# Altium schematic sheet mmb-2016-003_micro_phy.schdoc(mmb-2016-003_micro_phy)
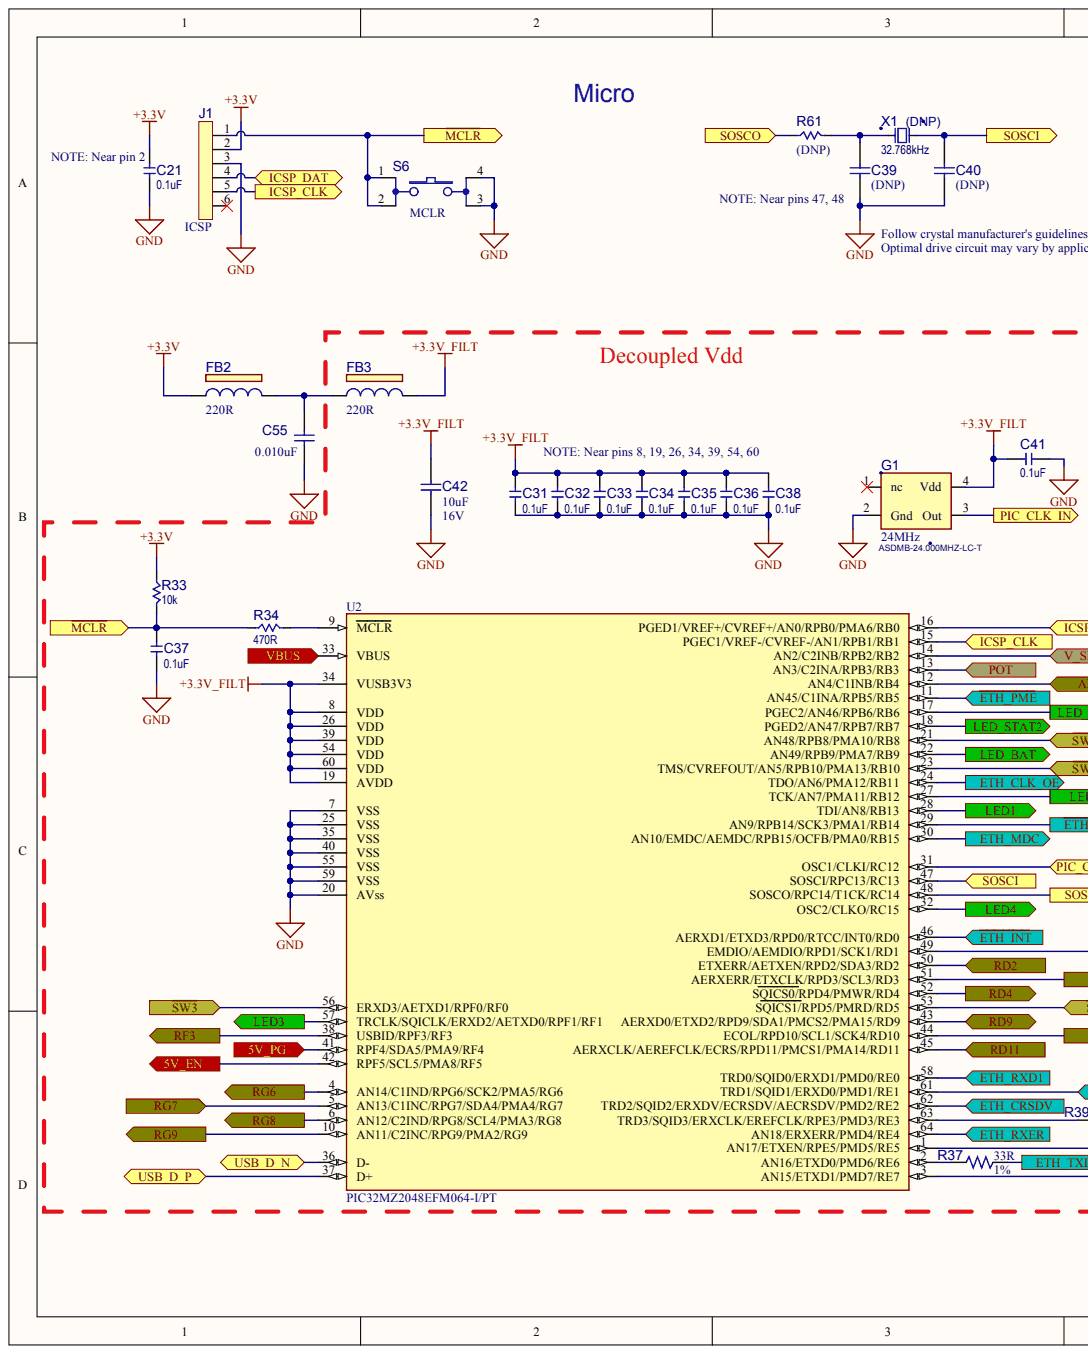
[diagram p0: mmb-2016-003_micro_phy.schdoc, left]
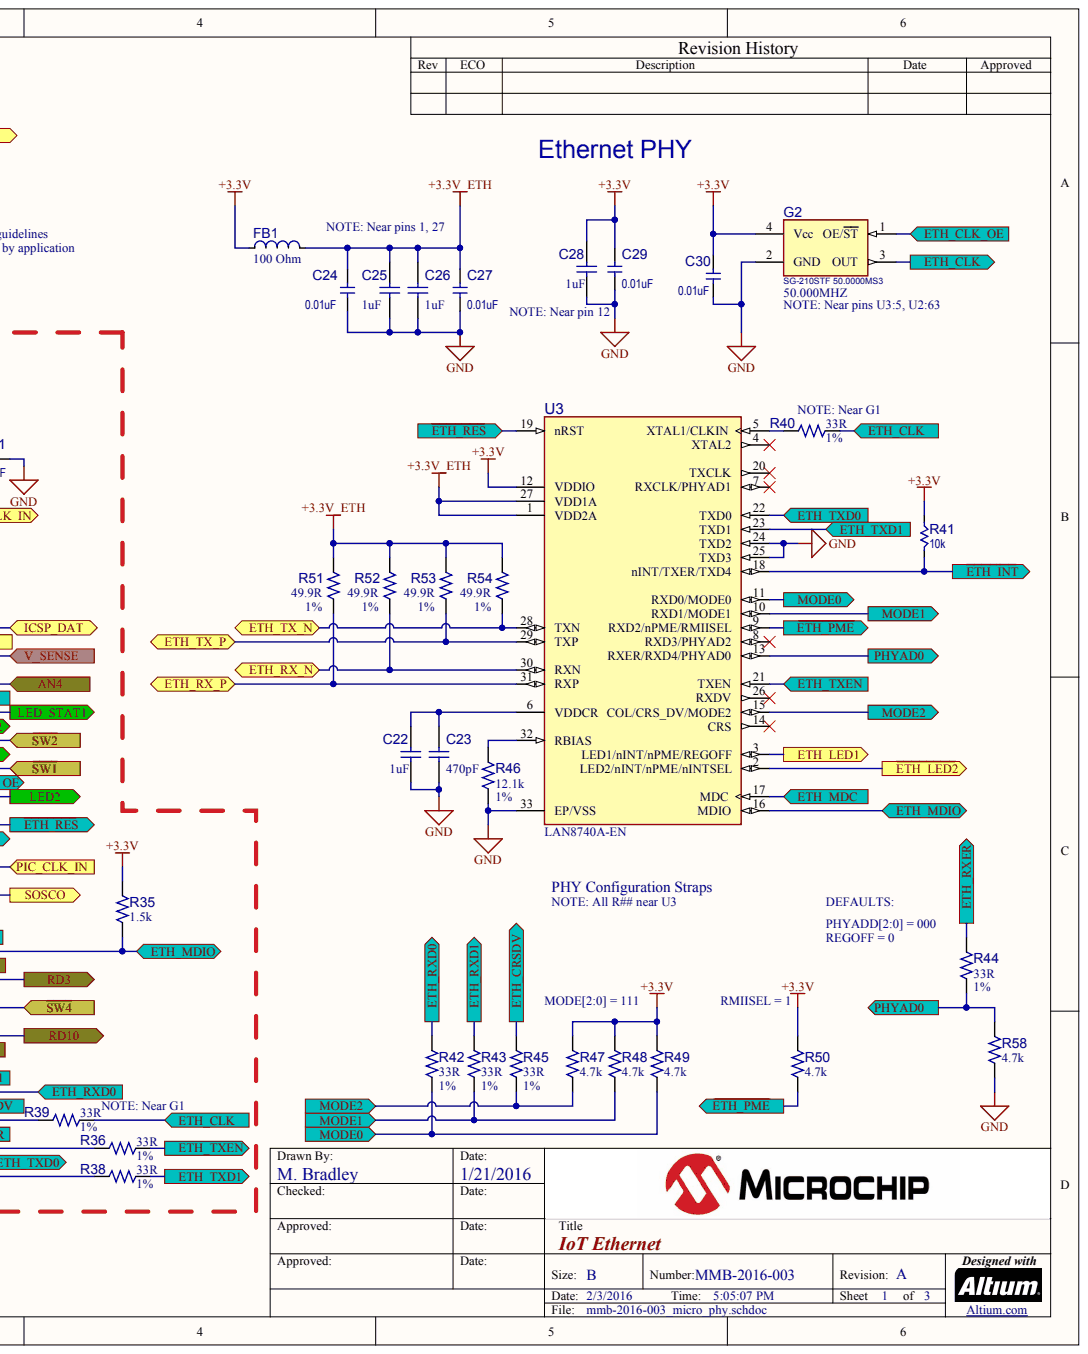
[diagram p1: mmb-2016-003_micro_phy.schdoc, right]

## Parts
C21  value 0.1uF  [CAP_C_0603]  (hidden comment: Capacitor NP)
C22  value 1uF  [CAP_C_0603]  (hidden comment: Capacitor NP)
C23  value 470pF  [CAP_C_0603]  (hidden comment: Capacitor NP)
C24  value 0.01uF  [CAP_C_0603]  (hidden comment: Capacitor NP)
C25  value 1uF  [CAP_C_0603]  (hidden comment: Capacitor NP)
C26  value 1uF  [CAP_C_0603]  (hidden comment: Capacitor NP)
C27  value 0.01uF  [CAP_C_0603]  (hidden comment: Capacitor NP)
C28  value 1uF  [CAP_C_0603]  (hidden comment: Capacitor NP)
C29  value 0.01uF  [CAP_C_0603]  (hidden comment: Capacitor NP)
C30  value 0.01uF  [CAP_C_0603]  (hidden comment: Capacitor NP)
C31  value 0.1uF  [CAP_C_0603]  (hidden comment: Capacitor NP)
C32  value 0.1uF  [CAP_C_0603]  (hidden comment: Capacitor NP)
C33  value 0.1uF  [CAP_C_0603]  (hidden comment: Capacitor NP)
C34  value 0.1uF  [CAP_C_0603]  (hidden comment: Capacitor NP)
C35  value 0.1uF  [CAP_C_0603]  (hidden comment: Capacitor NP)
C36  value 0.1uF  [CAP_C_0603]  (hidden comment: Capacitor NP)
C37  value 0.1uF  [CAP_C_0603]  (hidden comment: Capacitor NP)
C38  value 0.1uF  [CAP_C_0603]  (hidden comment: Capacitor NP)
C39  (DNP)  [CAP_C_0603]  (no BOM)
C40  (DNP)  [CAP_C_0603]  (no BOM)
C41  value 0.1uF  [CAP_C_0603]  (hidden comment: Capacitor NP)
C42  value 10uF  Voltage: 16V  [CAP_C_0805]  (hidden comment: (DNP))
C55  value 0.010uF  [CAP_C_0603]  (hidden comment: Capacitor NP)
FB1  value 100 Ohm  [IND_C_0603]  (hidden comment: Inductor)
FB2  value 220R  [IND_C_0805]  (hidden comment: Ferrite Bead)
FB3  value 220R  [IND_C_0805]  (hidden comment: Ferrite Bead)
G1  ASDMB-24.000MHZ-LC-T  value 24MHz  [CRYSTAL_ASDMB]
G2  SG-210STF 50.0000MS3  value 50.000MHZ  [CRYSTAL OSC_SG-210STF_L2.5W2.0H0.8]
J1  ICSP  [HDR_M_2.54_1x6_RA]
R33  value 10k  [RES_C_0603]  (hidden comment: Resistor)
R34  value 470R  [RES_C_0603]  (hidden comment: Resistor)
R35  value 1.5k  [RES_C_0603]  (hidden comment: Resistor)
R36  value 33R  Tolerance: 1%  [RES_C_0603]  (hidden comment: Resistor)
R37  value 33R  Tolerance: 1%  [RES_C_0603]  (hidden comment: Resistor)
R38  value 33R  Tolerance: 1%  [RES_C_0603]  (hidden comment: Resistor)
R39  value 33R  Tolerance: 1%  [RES_C_0603]  (hidden comment: Resistor)
R40  value 33R  Tolerance: 1%  [RES_C_0603]  (hidden comment: Resistor)
R41  value 10k  [RES_C_0603]  (hidden comment: Resistor)
R42  value 33R  Tolerance: 1%  [RES_C_0603]  (hidden comment: Resistor)
R43  value 33R  Tolerance: 1%  [RES_C_0603]  (hidden comment: Resistor)
R44  value 33R  Tolerance: 1%  [RES_C_0603]  (hidden comment: Resistor)
R45  value 33R  Tolerance: 1%  [RES_C_0603]  (hidden comment: Resistor)
R46  value 12.1k  Tolerance: 1%  [RES_C_0603]  (hidden comment: Resistor)
R47  value 4.7k  [RES_C_0603]  (hidden comment: Resistor)
R48  value 4.7k  [RES_C_0603]  (hidden comment: Resistor)
R49  value 4.7k  [RES_C_0603]  (hidden comment: Resistor)
R50  value 4.7k  [RES_C_0603]  (hidden comment: Resistor)
R51  value 49.9R  Tolerance: 1%  [RES_C_0603]  (hidden comment: Resistor)
R52  value 49.9R  Tolerance: 1%  [RES_C_0603]  (hidden comment: Resistor)
R53  value 49.9R  Tolerance: 1%  [RES_C_0603]  (hidden comment: Resistor)
R54  value 49.9R  Tolerance: 1%  [RES_C_0603]  (hidden comment: Resistor)
R58  value 4.7k  [RES_C_0603]  (hidden comment: Resistor)
R61  (DNP)  [RES_C_0603]  (no BOM)
S6  MCLR  [SW_SMD_6mmX6mm_TL3301]
U2  PIC32MZXXXXEFM064 QFN-64_TQFP-64  [TQFP-64_10x10]  (value not drawn)
U3  LAN8740A-EN  [QFN-32_5X5]
X1  (DNP)  value 32.768kHz  [CRYSTAL_ABS07]  (no BOM)

## Ports
5V_EN, 5V_PG, AN4, ETH_CLK, ETH_CLK_OE, ETH_CRSDV, ETH_LED1, ETH_MDC, ETH_MDIO, ETH_RX_N, ETH_RX_P, ETH_RXD0, ETH_RXD1, ETH_RXER, ETH_TX_N, ETH_TX_P, ETH_TXD0, ETH_TXD1, ETH_TXEN, ICSP_CLK, ICSP_DAT, LED1, LED2, LED3, LED4, LED_BAT, LED_STAT1, LED_STAT2, MODE0, MODE1, MODE2, PHYAD0, PIC_CLK_IN, POT, RD2, RD3, RD4, RD9, RD10, RD11, RF3, RG6, RG7, RG8, RG9, SOSCI, SOSCO, USB_D_N, USB_D_P, V_SENSE, VBUS, ~{ETH_INT}, ~{ETH_LED2}, ~{ETH_PME}, ~{ETH_RES}, ~{MCLR}, ~{SW1}, ~{SW2}, ~{SW3}, ~{SW4}

## Nets
+3.3V: C21.1 C28.1 C29.2 C30.1 FB1.1 FB2.1 G2.4(Vcc) J1.2 R33.2 R35.2 R41.2 R47.2 R48.2 R49.2 R50.2 U3.12(VDDIO)
+3.3V_ETH: C24.2 C25.1 C26.1 C27.2 FB1.2 R51.2 R52.2 R53.2 R54.2 U3.1(VDD2A) U3.27(VDD1A)
+3.3V_FILT: C31.2 C32.2 C33.2 C34.2 C35.2 C36.2 C38.2 C41.2 C42.2 FB3.2 G1.4(Vdd) U2.8(VDD) U2.19(AVDD) U2.26(VDD) U2.34(VUSB3V3) U2.39(VDD) U2.54(VDD) U2.60(VDD)
GND: C21.2 C22.1 C23.1 C24.1 C25.2 C26.2 C27.1 C28.2 C29.1 C30.2 C31.1 C32.1 C33.1 C34.1 C35.1 C36.1 C37.1 C38.1 C39.1 C40.1 C41.1 C42.1 C55.1 G1.2(Gnd) G2.2(GND) J1.3 R46.1 R58.1 S6.3 S6.4 U2.7(VSS) U2.20(AVss) U2.25(VSS) U2.35(VSS) U2.40(VSS) U2.55(VSS) U2.59(VSS) U3.24(TXD2) U3.25(TXD3) U3.33(EP/VSS)
5V_EN: U2.42(RPF5/SCL5/PMA8/RF5) ; port 5V_EN
5V_PG: U2.41(RPF4/SDA5/PMA9/RF4) ; port 5V_PG
AN4: U2.12(AN4/C1INB/RB4) ; port AN4
~{ETH_INT}: R41.1 U2.46(AERXD1/ETXD3/RPD0/RTCC/INT0/RD0) U3.18(nINT/TXER/TXD4) ; port ~{ETH_INT}
~{ETH_LED2}: U3.2(LED2/nINT/nPME/nINTSEL) ; port ~{ETH_LED2}
~{ETH_PME}: R50.1 U2.11(AN45/C1INA/RPB5/RB5) U3.9(RXD2/nPME/RMIISEL) ; port ~{ETH_PME}
~{ETH_RES}: U2.29(AN9/RPB14/SCK3/PMA1/RB14) U3.19(nRST) ; port ~{ETH_RES}
ETH_CLK: G2.3(OUT) R39.2 R40.2 ; port ETH_CLK
ETH_CLK_OE: G2.1(OE/ST) U2.24(TDO/AN6/PMA12/RB11) ; port ETH_CLK_OE
ETH_CRSDV: R45.2 U2.62(TRD2/SQID2/ERXDV/ECRSDV/AECRSDV/PMD2/RE2) ; port ETH_CRSDV
ETH_LED1: U3.3(LED1/nINT/nPME/REGOFF) ; port ETH_LED1
ETH_MDC: U2.30(AN10/EMDC/AEMDC/RPB15/OCFB/PMA0/RB15) U3.17(MDC) ; port ETH_MDC
ETH_MDIO: R35.1 U2.49(EMDIO/AEMDIO/RPD1/SCK1/RD1) U3.16(MDIO) ; port ETH_MDIO
ETH_RX_N: R52.1 U3.30(RXN) ; port ETH_RX_N
ETH_RX_P: R51.1 U3.31(RXP) ; port ETH_RX_P
ETH_RXD0: R42.2 U2.61(TRD1/SQID1/ERXD0/PMD1/RE1) ; port ETH_RXD0
ETH_RXD1: R43.2 U2.58(TRD0/SQID0/ERXD1/PMD0/RE0) ; port ETH_RXD1
ETH_RXER: R44.2 U2.64(AN18/ERXERR/PMD4/RE4) ; port ETH_RXER
ETH_TX_N: R54.1 U3.28(TXN) ; port ETH_TX_N
ETH_TX_P: R53.1 U3.29(TXP) ; port ETH_TX_P
ETH_TXD0: R37.2 U3.22(TXD0) ; port ETH_TXD0
ETH_TXD1: R38.2 U3.23(TXD1) ; port ETH_TXD1
ETH_TXEN: R36.2 U3.21(TXEN) ; port ETH_TXEN
ICSP_CLK: J1.5 U2.15(PGEC1/VREF-/CVREF-/AN1/RPB1/RB1) ; port ICSP_CLK
ICSP_DAT: J1.4 U2.16(PGED1/VREF+/CVREF+/AN0/RPB0/PMA6/RB0) ; port ICSP_DAT
LED1: U2.28(TDI/AN8/RB13) ; port LED1
LED2: U2.27(TCK/AN7/PMA11/RB12) ; port LED2
LED3: U2.57(TRCLK/SQICLK/ERXD2/AETXD0/RPF1/RF1) ; port LED3
LED4: U2.32(OSC2/CLKO/RC15) ; port LED4
LED_BAT: U2.22(AN49/RPB9/PMA7/RB9) ; port LED_BAT
LED_STAT1: U2.17(PGEC2/AN46/RPB6/RB6) ; port LED_STAT1
LED_STAT2: U2.18(PGED2/AN47/RPB7/RB7) ; port LED_STAT2
~{MCLR}: C37.2 J1.1 R33.1 R34.2 S6.1 S6.2 ; port ~{MCLR}
MODE0: R42.1 R49.1 U3.11(RXD0/MODE0) ; port MODE0
MODE1: R43.1 R48.1 U3.10(RXD1/MODE1) ; port MODE1
MODE2: R45.1 R47.1 U3.15(COL/CRS_DV/MODE2) ; port MODE2
PHYAD0: R44.1 R58.2 U3.13(RXER/RXD4/PHYAD0) ; port PHYAD0
PIC_CLK_IN: G1.3(Out) U2.31(OSC1/CLKI/RC12) ; port PIC_CLK_IN
POT: U2.13(AN3/C2INA/RPB3/RB3) ; port POT
RD2: U2.50(ETXERR/AETXEN/RPD2/SDA3/RD2) ; port RD2
RD3: U2.51(AERXERR/ETXCLK/RPD3/SCL3/RD3) ; port RD3
RD4: U2.52(SQICS0/RPD4/PMWR/RD4) ; port RD4
RD9: U2.43(AERXD0/ETXD2/RPD9/SDA1/PMCS2/PMA15/RD9) ; port RD9
RD10: U2.44(ECOL/RPD10/SCL1/SCK4/RD10) ; port RD10
RD11: U2.45(AERXCLK/AEREFCLK/ECRS/RPD11/PMCS1/PMA14/RD11) ; port RD11
RF3: U2.38(USBID/RPF3/RF3) ; port RF3
RG6: U2.4(AN14/C1IND/RPG6/SCK2/PMA5/RG6) ; port RG6
RG7: U2.5(AN13/C1INC/RPG7/SDA4/PMA4/RG7) ; port RG7
RG8: U2.6(AN12/C2IND/RPG8/SCL4/PMA3/RG8) ; port RG8
RG9: U2.10(AN11/C2INC/RPG9/PMA2/RG9) ; port RG9
~{SW1}: U2.23(TMS/CVREFOUT/AN5/RPB10/PMA13/RB10) ; port ~{SW1}
~{SW2}: U2.21(AN48/RPB8/PMA10/RB8) ; port ~{SW2}
~{SW3}: U2.56(ERXD3/AETXD1/RPF0/RF0) ; port ~{SW3}
~{SW4}: U2.53(SQICS1/RPD5/PMRD/RD5) ; port ~{SW4}
SOSCI: C40.2 U2.47(SOSCI/RPC13/RC13) X1.2 ; port SOSCI
SOSCO: R61.2 U2.48(SOSCO/RPC14/T1CK/RC14) ; port SOSCO
USB_D_N: U2.36(D-) ; port USB_D_N
USB_D_P: U2.37(D+) ; port USB_D_P
V_SENSE: U2.14(AN2/C2INB/RPB2/RB2) ; port V_SENSE
VBUS: U2.33(VBUS) ; port VBUS
NetC22_2: C22.2 C23.2 U3.6(VDDCR)
NetC39_2: C39.2 R61.1 X1.1
NetC55_2: C55.2 FB2.2 FB3.1
NetR34_1: R34.1 U2.9(MCLR)
NetR36_1: R36.1 U2.1(AN17/ETXEN/RPE5/PMD5/RE5)
NetR37_1: R37.1 U2.2(AN16/ETXD0/PMD6/RE6)
NetR38_1: R38.1 U2.3(AN15/ETXD1/PMD7/RE7)
NetR39_1: R39.1 U2.63(TRD3/SQID3/ERXCLK/EREFCLK/RPE3/PMD3/RE3)
NetR40_1: R40.1 U3.5(XTAL1/CLKIN)
NetR46_2: R46.2 U3.32(RBIAS)

Not connected: G1 1(nc); J1 6; U3 4(XTAL2) 7(RXCLK/PHYAD1) 8(RXD3/PHYAD2) 14(CRS) 20(TXCLK) 26(RXDV)

## Library data of the parts
C21 C31-C38 C41 = CAP1166: Capacitor; Manufacturer 1: TDK; Manufacturer Part Number 1: C1608X7R1C104K; Description_: CAP CER 0.1uF 16V 10% X7R 0603; Owner: Bogdan P (M91398); Populated: Yes; Temperature Coeffcient: X7R; Tolerance: 10%; Type: Ceramic; Voltage: 16V; Category: Capacitor; Component Kind: Standard; ComponentLink1Description: Datasheet; ComponentLink1URL: https://chn-vm-apps.microchip.com/mchprepo/parts/Datasheets/general_B11.pdf; Database Table Name: Capacitor; Library Name: MCHP-CDB.DbLib; MCHP-ID: CAP1166; Operating Temperature: -55C to +125C; Package: 0603; Quantity Override: 1; Revision: 0; Status: Production; Supplier 1: Digi-Key; Supplier Part Number 1: 445-1317-1-ND
C22 C25 C26 C28 = CAP0265: Capacitor; Manufacturer 1: AVX; Manufacturer Part Number 1: 0603YD105KAT2A; Description_: CAP CER 1uF 16V 10% X5R SMD 0603; Populated: Yes; Temperature Coeffcient: X5R; Tolerance: 10%; Type: Ceramic; Voltage: 16V; Category: Capacitor; Component Kind: Standard; ComponentLink1Description: Datasheet; ComponentLink1URL: https://chn-vm-apps.microchip.com/mchprepo/parts/Datasheets/smccp.pdf; Database Table Name: Capacitor; Library Name: MCHP-CDB-Offline.DbLib; Manufacturer 2: Murata; Manufacturer 3: Murata; Manufacturer 4: Panasonic; Manufacturer 5: Taiyo Yuden; Manufacturer 6: TDK; Manufacturer Part Number 2: GRM188R61C105KA93D; Manufacturer Part Number 3: GRM185R61C105KE44D; Manufacturer Part Number 4: ECJ-1VB1C105K; Manufacturer Part Number 5: EMK107BJ105KA-T; Manufacturer Part Number 6: C1608X5R1C105K; MCHP-ID: CAP0265; Operating Temperature: -55C to +85C; Package: 0603; Quantity Override: 1; Revision: 1; Status: Production; Supplier 1: Digi-Key; Supplier 2: Digi-Key; Supplier 3: Digi-Key; Supplier 4: Digi-Key; Supplier 5: Digi-Key; Supplier 6: Digi-Key; Supplier Part Number 1: 478-1249-1-ND; Supplier Part Number 2: 490-1536-1-ND; Supplier Part Number 3: 490-3894-1-ND; Supplier Part Number 4: PCC2224CT-ND; Supplier Part Number 5: 587-1251-1-ND; Supplier Part Number 6: 445-1416-1-ND
C23 = CAP0603: Capacitor; Manufacturer 1: AVX; Manufacturer Part Number 1: 06033A471JAT2A; Description_: CAP CER 470pF 25V 5% NP0 SMD 0603; Populated: Yes; Temperature Coeffcient: NP0; Tolerance: 5%; Type: Ceramic; Voltage: 25V; Category: Capacitor; Component Kind: Standard; ComponentLink1Description: Datasheet; ComponentLink1URL: https://chn-vm-apps.microchip.com/mchprepo/parts/Datasheets/smccp.pdf; Database Table Name: Capacitor; Library Name: MCHP-CDB-Offline.DbLib; MCHP-ID: CAP0603; Operating Temperature: -55C to +125C; Package: 0603; Quantity Override: 1; Revision: 1; Status: Production; Supplier 1: Digi-Key; Supplier Part Number 1: 478-6201-1-ND
C24 C27 C29 C30 = CAP0451: Capacitor; Manufacturer 1: Panasonic; Manufacturer Part Number 1: ECJ-1VB1C103K; Description_: CAP CER 0.01uF 16V 10% X7R SMD 0603; Populated: Yes; Temperature Coeffcient: X7R; Tolerance: 10%; Type: Ceramic; Voltage: 16V; Category: Capacitor; Component Kind: Standard; ComponentLink1Description: Datasheet; ComponentLink1URL: https://chn-vm-apps.microchip.com/mchprepo/parts/Datasheets/L0005E.pdf; Database Table Name: Capacitor; Library Name: MCHP-CDB.DbLib; Manufacturer 2: Murata; Manufacturer 3: Johanson Dielectrics; Manufacturer Part Number 2: GRM188R71C103KA01D; Manufacturer Part Number 3: 160R14W103KV4T; MCHP-ID: CAP0451; Operating Temperature: -55C to +125C; Package: 0603; Quantity Override: 1; Revision: 1; Status: Production; Supplier 1: Digi-Key; Supplier 2: Digi-Key; Supplier 3: Digi-Key; Supplier Part Number 1: PCC1750CT-ND; Supplier Part Number 2: 490-1525-1-ND; Supplier Part Number 3: 709-1152-2-ND
C39 C40 = CAP1123: Capacitor; Manufacturer 1: TDK; Manufacturer Part Number 1: C1608C0G1H180J; Description_: CAP CER 18pF 50V 5% C0G SMD 0603; Dev Tools Status: Production; Owner: Bogdan P (M91398); Populated: Yes; Temperature Coeffcient: C0G; Tolerance: 5%; Type: Ceramic; Value: 18pF; Voltage: 50V; Category: Capacitor; ComponentLink1Description: Datasheet; ComponentLink1URL: https://chn-vm-apps.microchip.com/mchprepo/parts/Datasheets/general_B11.pdf; Database Table Name: Capacitor; Library Name: MCHP-CDB.DbLib; MCHP-ID: CAP1123; Operating Temperature: -55C to +125C; Package: 0603; Quantity Override: 1; Revision: 1; Status: MCL Design; Supplier 1: Digi-Key; Supplier Part Number 1: 445-1272-1-ND
C42 = CAP0373: Capacitor; Manufacturer 1: Murata; Manufacturer Part Number 1: GRM21BR61C106KE15L; Description_: CAP CER 10uF 16V 10% X5R SMD 0805; Dev Tools Status: Production; Populated: Yes; Temperature Coeffcient: X5R; Tolerance: 10%; Type: Ceramic; Category: Capacitor; Component Kind: Standard; ComponentLink1Description: Datasheet; ComponentLink1URL: https://chn-vm-apps.microchip.com/mchprepo/parts/Datasheets/L0005E.pdf; Database Table Name: Capacitor; Library Name: MCHP-CDB.DbLib; Manufacturer 2: Taiyo Yuden; Manufacturer Part Number 2: EMK212BJ106KG-T; MCHP-ID: CAP0373; Operating Temperature: +25C to +125C; Package: 0805; Quantity Override: 1; Revision: 1; Status: MCL Design; Supplier 1: Digi-Key; Supplier 2: Digi-Key; Supplier 3: Newark; Supplier Part Number 1: 490-3886-1-ND; Supplier Part Number 2: 587-1295-1-ND; Supplier Part Number 3: 30K5459
C55 = CAP0602: Capacitor; Manufacturer 1: Yageo; Manufacturer Part Number 1: CC0603KRX7R8BB103; Description_: CAP CER 0.010uF 25V 10% X7R SMD 0603; Dev Tools Status: Production; Populated: Yes; Temperature Coeffcient: X7R; Tolerance: 10%; Type: Ceramic; Voltage: 25V; Category: Capacitor; Component Kind: Standard; ComponentLink1Description: Datasheet; ComponentLink1URL: https://chn-vm-apps.microchip.com/mchprepo/parts/Datasheets/MLCC_ordering_code.pdf; Database Table Name: Capacitor; Library Name: MCHP-CDB.DbLib; MCHP-ID: CAP0602; Operating Temperature: -55C to +125C; Package: 0603; Quantity Override: 1; Revision: 1; Status: MCL Design; Supplier 1: Digi-Key; Supplier Part Number 1: 311-1360-1-ND
FB1 = IND1123: Manufacturer 1: TDK Corporation; Manufacturer Part Number 1: MPZ1608Y101B; Description_: FERRITE CHIP 100 OHM 2A 0603; Owner: Sompoch Loboonlert; Populated: YES; Type: Ferrite Bead; Category: Inductor; Component Kind: Standard; ComponentLink1Description: Datasheet; ComponentLink1URL: https://chn-vm-apps.microchip.com/mchprepo/parts/Datasheets/beads_commercial_power_mpz_en.pdf; Database Table Name: Inductor; Description: Inductor; Library Name: MCHP-CDB.DbLib; MCHP-ID: IND1123; Package: 0603; Quantity Override: 1; Revision: 1; Status: Design; Supplier 1: Digi-Key; Supplier Part Number 1: 445-2984-1-ND
FB2 FB3 = IND1092: Manufacturer 1: Murata Electronics North America; Manufacturer Part Number 1: BLM21PG221SN1D; Database Table Name: Inductor; DCR: 0.045R; Description_: FERRITE 2A 220R SMD 0805; Dev Tools Status: Production; Owner: Stephen Humberd; Populated: YES; Test Frequency: 100MHz; Category: Inductor; Component Kind: Standard; ComponentLink1Description: Datasheet; ComponentLink1URL: https://chn-vm-apps.microchip.com/mchprepo/parts/Datasheets/L0110S0100BLM21P.pdf; Library Name: MCHP-CDB.DbLib; MCHP-ID: IND1092; Operating Temperature: -55C to +125C; Package: 0805; Quantity Override: 1; Revision: B; Status: MCL Design; Supplier 1: Digi-Key; Supplier Part Number 1: 490-1054-1-ND; Type: Ferrite Bead
G1 = OSC1056: Crystal; Manufacturer 1: Abracon Corporation; Database Table Name: Crystal-Oscillator; Description_: OSC MEMS 24.000 MHZ SMD; Dev Tools Status: Design; Owner: Randolph Sobrepena; Populated: YES; Supply Current: 16mA; Voltage: 1.8 V - 3.3 V; Category: Crystal/Oscillator; Component Kind: Standard; ComponentLink1Description: Datasheet; ComponentLink1URL: https://chn-vm-apps.microchip.com/mchprepo/parts/Datasheets/ASDMB.pdf; Library Name: MCHP-CDB.DbLib; Manufacturer Part Number 1: ASDMB-24.000MHZ-LC-T; MCHP-ID: OSC1056; Operating Temperature: -40C to +85C; Package: DFN; Quantity Override: 1; Revision: 0; Status: MCL Design; Supplier 1: Digi-Key; Supplier Part Number 1: 535-11728-1-ND; Type: Oscillator
G2 = OSC1068: OSC XO 50.000MHZ CMOS SMD; Manufacturer 1: Epson; Database Table Name: Crystal-Oscillator; Dev Tools Status: Production; Owner: Duane Burt; Populated: YES; Category: Crystal/Oscillator; Component Kind: Standard; Description_: CRYSTAL OSC_SG-210STF_L2.5W2.0H0.8; Library Name: MCHP-CDB.DbLib; Manufacturer Part Number 1: SG-210STF 50.0000MS3; MCHP-ID: OSC1068; Package: L2.5W2.0H0.8; Quantity Override: 1; Revision: 1; Status: MCL Design; Supplier 1: Digikey; Supplier Part Number 1: SER3808CT-ND; Type: Crystal
J1 = CON1017: Manufacturer 1: FCI; Manufacturer Part Number 1: 68016-106HLF; Database Table Name: Connector; Description_: CON HDR-2.54 Male 1x6 Gold 5.84MH TH R/A; Owner: Leah Whitaker; Populated: YES; Value: HDR-2.54 Male 1x6; Category: Connector; Component Kind: Standard; ComponentLink1Description: Datasheet; ComponentLink1URL: https://chn-vm-apps.microchip.com/mchprepo/parts/Datasheets/68015.pdf; Library Name: MCHP-CDB.DbLib; MCHP-ID: CON1017; Quantity Override: 1; Revision: 1; Status: Production; Supplier 1: Digi-Key; Supplier Part Number 1: 609-3313-ND; Type: Header 2.54mm
R33 R41 = RES0191: Manufacturer 1: Panasonic; Manufacturer Part Number 1: ERJ-3GEYJ103V; Description_: RES TKF 10k 5% 1/10W SMD 0603; Populated: Yes; Power: 1/10W; Temperature Coeffcient: 200ppm/C; Tolerance: 5%; Voltage: 75V; Category: Resistor; Component Kind: Standard; ComponentLink1Description: Datasheet; ComponentLink1URL: https://chn-vm-apps.microchip.com/mchprepo/parts/Datasheets/AOA0000CE1.pdf; Composition: TKF; Database Table Name: Resistor; Description: Resistor; Library Name: MCHP-CDB.DbLib; Manufacturer 2: NIC Components; Manufacturer 3: Yageo; Manufacturer Part Number 2: NRC06J103TRF; Manufacturer Part Number 3: RC0603JR-0710KL; MCHP-ID: RES0191; Operating Temperature: -55C to +155C; Package: 0603; Quantity Override: 1; Revision: 2; Status: Production; Supplier 1: Digi-Key; Supplier 2: Digi-Key; Supplier 3: NIC Components; Supplier 4: Digi-Key; Supplier Part Number 1: P10KGCT-ND; Supplier Part Number 2: P10KGTR-ND; Supplier Part Number 3: NRC06J103TRF; Supplier Part Number 4: 311-10KGRTR-ND; Type: RES SMD
R34 = RSMT0098: Manufacturer 1: NIC Components; Manufacturer Part Number 1: NRC06J471TRF; Description_: RES TKF 470R 5% 1/10W SMD 0603; Populated: Yes; Power: 1/10W; Temperature Coeffcient: 200ppm/C; Tolerance: 5%; Category: Resistor; Component Kind: Standard; ComponentLink1Description: Datasheet; ComponentLink1URL: https://chn-vm-apps.microchip.com/mchprepo/parts/Datasheets/nrc.pdf; Composition: TKF; Database Table Name: Resistor; Description: Resistor; Library Name: MCHP-CDB.DbLib; Manufacturer 2: Panasonic; Manufacturer 3: Stackpole Electronics Inc; Manufacturer Part Number 2: ERJ-3GEYJ471V; Manufacturer Part Number 3: RMCF0603JT470R; MCHP-ID: RSMT0098; Operating Temperature: -55C to +155C; Package: 0603; Quantity Override: 1; Revision: 1; Status: Production; Supplier 1: NIC Components; Supplier 2: Digi-Key; Supplier 3: Digi-Key; Supplier Part Number 1: NRC06J471TRF; Supplier Part Number 2: P470GTR-ND; Supplier Part Number 3: RMCF0603JT470RCT-ND; Type: RES SMD
R35 = RSMT0164: Manufacturer 1: Panasonic; Manufacturer Part Number 1: ERJ-3GEYJ152V; Description_: RES TKF 1.5k 5% 1/10W SMD 0603; Populated: Yes; Power: 1/10W; Temperature Coeffcient: 100ppm/C; Tolerance: 5%; Voltage: 50V; Category: Resistor; Component Kind: Standard; ComponentLink1Description: Datasheet; ComponentLink1URL: https://chn-vm-apps.microchip.com/mchprepo/parts/Datasheets/PYu-RC0603_51_RoHS_L_4.pdf; Composition: TKF; Database Table Name: Resistor; Description: Resistor; Library Name: MCHP-CDB-Offline.DbLib; Manufacturer 2: Yageo; Manufacturer 3: NIC Components; Manufacturer 4: Stackpole Electronics Inc; Manufacturer 5: Yageo; Manufacturer 6: Yageo; Manufacturer Part Number 2: 9C06031A1501JLHFT; Manufacturer Part Number 3: NRC06J152TRF; Manufacturer Part Number 4: RMCF 1/16 1.5K 5% R; Manufacturer Part Number 5: RC0603JR-071K5L; Manufacturer Part Number 6: 9C06031A1501JLPFT; MCHP-ID: RSMT0164; Operating Temperature: -55C to +155C; Package: 0603; Quantity Override: 1; Revision: 1; Status: Production; Supplier 1: Digi-Key; Supplier 2: Digi-Key; Supplier 3: Digi-Key; Supplier 4: NIC Components; Supplier 5: Stackpole Electronics Inc; Supplier 6: Yageo; Supplier 7: Yageo; Supplier Part Number 1: P1.5KGTR-ND; Supplier Part Number 2: P1.5KGCT-ND; Supplier Part Number 3: 311-1.5KGTR-ND; Supplier Part Number 4: NRC06J152TRF; Supplier Part Number 5: RMCF 1/16 1.5K 5% R; Supplier Part Number 6: RC0603JR-071K5L; Supplier Part Number 7: 9C06031A1501JLPFT; Type: RES SMD
R36-R40 R42-R45 = RSMT0701: Manufacturer 1: ROHM; Manufacturer Part Number 1: MCR03EZPFX33R0; Description_: RES TKF 33R 1% 1/10W SMD 0603; Populated: Yes; Power: 1/10W; Temperature Coeffcient: 100ppm/C; Voltage: 50V; Category: Resistor; Component Kind: Standard; ComponentLink1Description: Datasheet; ComponentLink1URL: https://chn-vm-apps.microchip.com/mchprepo/parts/Datasheets/mcr_a.pdf; Composition: TKF; Database Table Name: Resistor; Description: Resistor; Library Name: MCHP-CDB-Offline.DbLib; Manufacturer 2: Stackpole Electronics Inc; Manufacturer Part Number 2: RMCF00603FT33R0; MCHP-ID: RSMT0701; Operating Temperature: -55C to +155C; Package: 0603; Quantity Override: 1; Revision: 1; Status: Production; Supplier 1: Digi-Key; Supplier 2: Digi-Key; Supplier Part Number 1: RHM33.0HCT-ND; Supplier Part Number 2: RMCF0603FT33R0CT-ND; Type: RES SMD
R46 = RSMT0414: Manufacturer 1: Panasonic; Manufacturer Part Number 1: ERJ-3EKF1212V; Description_: RES TKF 12.1k 1% 1/10W SMD 0603; Populated: Yes; Power: 1/10W; Temperature Coeffcient: 100ppm/C; Voltage: 75V; Category: Resistor; Component Kind: Standard; ComponentLink1Description: Datasheet; ComponentLink1URL: https://chn-vm-apps.microchip.com/mchprepo/parts/Datasheets/AOA0000CE2.pdf; Composition: TKF; Database Table Name: Resistor; Description: Resistor; Library Name: MCHP-CDB-Offline.DbLib; Manufacturer 2: ROHM; Manufacturer 3: SET; Manufacturer Part Number 2: MCR03EZPFX1212; Manufacturer Part Number 3: RMCF1/1612.1K1%TR; MCHP-ID: RSMT0414; Operating Temperature: -55C to +155C; Package: 0603; Quantity Override: 1; Revision: 1; Status: Production; Supplier 1: Digi-Key; Supplier 2: Digi-Key; Supplier 3: SET; Supplier Part Number 1: P12.1KHCT-ND; Supplier Part Number 2: RHM12.1KHCT-ND; Supplier Part Number 3: RMCF1/1612.1K1%TR; Type: RES SMD
R47-R50 R58 = RSMT0092: Manufacturer 1: Yageo; Manufacturer Part Number 1: RC0603JR-074K7L; Description_: RES TKF 4.7k 5% 1/10W SMD 0603; Populated: Yes; Power: 1/10W; Temperature Coeffcient: 100ppm/C; Tolerance: 5%; Voltage: 50V; Category: Resistor; Component Kind: Standard; ComponentLink1Description: Datasheet; ComponentLink1URL: https://chn-vm-apps.microchip.com/mchprepo/parts/Datasheets/PYu-RC0603_51_RoHS_L_4.pdf; Composition: TKF; Database Table Name: Resistor; Description: Resistor; Library Name: MCHP-CDB-Offline.DbLib; Manufacturer 2: Yageo; Manufacturer 3: KOA Speer; Manufacturer 4: Panasonic; Manufacturer 5: ROHM; Manufacturer 6: Stackpole Electronics Inc; Manufacturer 7: Yageo; Manufacturer 8: NIC Components; Manufacturer Part Number 2: 9C06031A4701JLHFT; Manufacturer Part Number 3: RK73B1JTTD472J; Manufacturer Part Number 4: ERJ-3GEYJ472V; Manufacturer Part Number 5: MCR03EZHJ4.7K; Manufacturer Part Number 6: RMCF 1/16 4.7K 5% R; Manufacturer Part Number 7: 9C06031A4701JLPFT; Manufacturer Part Number 8: NRC06J472TRF; MCHP-ID: RSMT0092; Operating Temperature: -55C to +155C; Package: 0603; Quantity Override: 1; Revision: 1; Status: Production; Supplier 1: Digi-Key; Supplier 2: Digi-Key; Supplier 3: KOA Speer; Supplier 4: Digi-Key; Supplier 5: Digi-Key; Supplier 6: ROHM; Supplier 7: Stackpole Electronics Inc; Supplier 8: Yageo; Supplier 9: Avnet; Supplier Part Number 1: 311-4.7KGRTR-ND; Supplier Part Number 2: 311-4.7KGTR-ND; Supplier Part Number 3: RK73B1JTTD472J; Supplier Part Number 4: P4.7KGTR-ND; Supplier Part Number 5: P4.7KGCT-ND; Supplier Part Number 6: MCR03EZHJ4.7K; Supplier Part Number 7: RMCF 1/16 4.7K 5% R; Supplier Part Number 8: 9C06031A4701JLPFT; Supplier Part Number 9: NRC06J472TRF; Type: RES SMD
R51-R54 = RES1188: Manufacturer 1: Panasonic; Manufacturer Part Number 1: ERJ-3EKF49R9V; Description_: RES TKF 49.9R 1% 1/10W SMD 0603; Owner: Adrian T (M91397); Populated: Yes; Power: 1/10W; Temperature Coeffcient: 100ppm/C; Voltage: 75V; Category: Resistor; Component Kind: Standard; ComponentLink1Description: Datasheet; ComponentLink1URL: https://chn-vm-apps.microchip.com/mchprepo/parts/Datasheets/AOA0000CE2.pdf; Composition: TKF; Database Table Name: Resistor; Description: Resistor; Library Name: MCHP-CDB-Offline.DbLib; MCHP-ID: RES1188; Operating Temperature: -55C to +155C; Package: 0603; Quantity Override: 1; Revision: 0; Status: Production; Supplier 1: Digi-Key; Supplier Part Number 1: P49.9HCT-ND; Type: RES SMD
R61 = RES0191: Resistor; Manufacturer 1: Panasonic; Manufacturer Part Number 1: ERJ-3GEYJ103V; Description_: RES TKF 10k 5% 1/10W SMD 0603; Populated: Yes; Power: 1/10W; Temperature Coeffcient: 200ppm/C; Tolerance: 5%; Value: 10k; Voltage: 75V; Category: Resistor; ComponentLink1Description: Datasheet; ComponentLink1URL: https://chn-vm-apps.microchip.com/mchprepo/parts/Datasheets/AOA0000CE1.pdf; Composition: TKF; Database Table Name: Resistor; Library Name: MCHP-CDB.DbLib; Manufacturer 2: NIC Components; Manufacturer 3: Yageo; Manufacturer Part Number 2: NRC06J103TRF; Manufacturer Part Number 3: RC0603JR-0710KL; MCHP-ID: RES0191; Operating Temperature: -55C to +155C; Package: 0603; Quantity Override: 1; Revision: 2; Status: Production; Supplier 1: Digi-Key; Supplier 2: Digi-Key; Supplier 3: NIC Components; Supplier 4: Digi-Key; Supplier Part Number 1: P10KGCT-ND; Supplier Part Number 2: P10KGTR-ND; Supplier Part Number 3: NRC06J103TRF; Supplier Part Number 4: 311-10KGRTR-ND; Type: RES SMD
S6 = SWITCH0095: Switch; Manufacturer 1: E-Switch; Manufacturer Part Number 1: TL3301AF160QG; Current: 50mA; Description_: SWITCH SPST 12V 50mA TL3301AF160QG SMD; Populated: Yes; Value: SPST; Voltage: 12V; Category: Switch; Component Kind: Standard; ComponentLink1Description: Datasheet; ComponentLink1URL: https://chn-vm-apps.microchip.com/mchprepo/parts/Datasheets/TL3301.pdf; Database Table Name: Switch; Library Name: MCHP-CDB.DbLib; MCHP-ID: SWITCH0095; Operating Temperature: -20C to +70C; Quantity Override: 1; Revision: 1; Status: Production; Supplier 1: Digi-Key; Supplier Part Number 1: EG2526CT-ND
U2 = MIC6518: Manufacturer 1: Microchip Technology; Manufacturer Part Number 1: PIC32MZ2048EFM064-I/PT; ADCBit: 12; ADC Channels: 24; CAN: 2; CapTouchCH: 0; Database Table Name: MCHP-Microcontroller; Description_: MCHP MCU 32-BIT 200MHz 2048kB 512kB PIC32MZ2048EFM064-I/PT TQFP-64; Dev Tools Status: Design; DigitalTimerQty_16bit: 9; DigitalTimerQty_32bit: 4; ForceMinOrderQtyMult: False; IOPins: 53; LeadContent: Pb-FREE; LeadCount: 64; MAPS Description: 32 Bit MCU, FPU, 2MB Flash, 512KB RAM, 200MHz, 64Pin, USB-HS, ENET, CAN, Crypto; MaxSpeed: 200; MinOrderQty: 1; MPC: WACD17V2XNXF; OscillatorMHz: 8 MHz, 32 kHz; Owner: Gabor Bihari; PackageWidth: 10x10x1mm; PackingMedia: TRAY; Populated: YES; Program Memory KBytes: 2048; Program Memory KWords: 512; Ram: 524288; Stage: REL; TempRange: -40C to +85C; USART: 6; Value: PIC32MZ2048EFM064-I/PT; Category: MCHP-Microcontroller; Comparators: 2; Component Kind: Standard; ComponentLink1Description: Datasheet; ComponentLink1URL: http://www.microchip.com/wwwproducts/Devices.aspx?product=PIC32MZ2048EFM064; DAChannels: 0; DigitalTimerQty_8bit: 0; EEPROM: 0; Family: 32-bit MCU; I2C: 4; Input Capture: 9; IntroductionDate: 2015-07-09; Library Name: MCHP-CDB.DbLib; MCHP-ID: MIC6518; mtrlcntrlpwmchannels: 0; PackageType: TQFP; PWM Channels: 9; QEI Channels: 0; Quantity Override: 1; Revision: A; SegmentLCD: 0; SMPS PWM Channels: 0; SPI: 4; Status: MCL Design; Supplier 1: Digi-Key; Supplier Part Number 1: PIC32MZ2048EFM064-I/PT-ND; Type: 32-Bit; USBChannels: 1
U3 = MIC6357: IC ETHERNET PHY; Manufacturer 1: Microchip Technology Inc; Database Table Name: MCHP-Interface; Dev Tools Status: Production; Owner: Duane Burt; Populated: YES; Category: MCHP-Interface; Component Kind: Standard; ComponentLink1Description: Datasheet; Description_: LAN8740A-EN; Library Name: MCHP-CDB.DbLib; Manufacturer Part Number 1: LAN8740A-EN; MCHP-ID: MIC6357; Quantity Override: 1; Revision: 1; Status: MCL Design; Supplier 1: Digikey; Supplier Part Number 1: LAN8740A-EN-ND; Type: Ethernet; Value: LAN8740A-EN
X1 = OSC1114: Crystal; Manufacturer 1: Abracon; Manufacturer Part Number 1: ABS07-32.768KHZ-1-T; Database Table Name: Crystal-Oscillator; Description_: CRYSTAL 32.768kHz 12.5pF SMD ABS07; Load Capacitance: 12.5pF; Owner: Adrian M (M91404); Populated: Yes; Tolerance: 10ppm; Category: Crystal/Oscillator; ComponentLink1Description: Datasheet; ComponentLink1URL: https://chn-vm-apps.microchip.com/mchprepo/parts/Datasheets/ABS07.pdf; Library Name: MCHP-CDB.DbLib; MCHP-ID: OSC1114; Operating Temperature: -40C to +85C; Package: SMD_ABS07; Quantity Override: 1; Revision: 0; Status: Production; Supplier 1: Digi-Key; Supplier Part Number 1: 535-9808-1-ND; Type: Crystal
